# Altium schematic sheet RC96_sht1.SchDoc
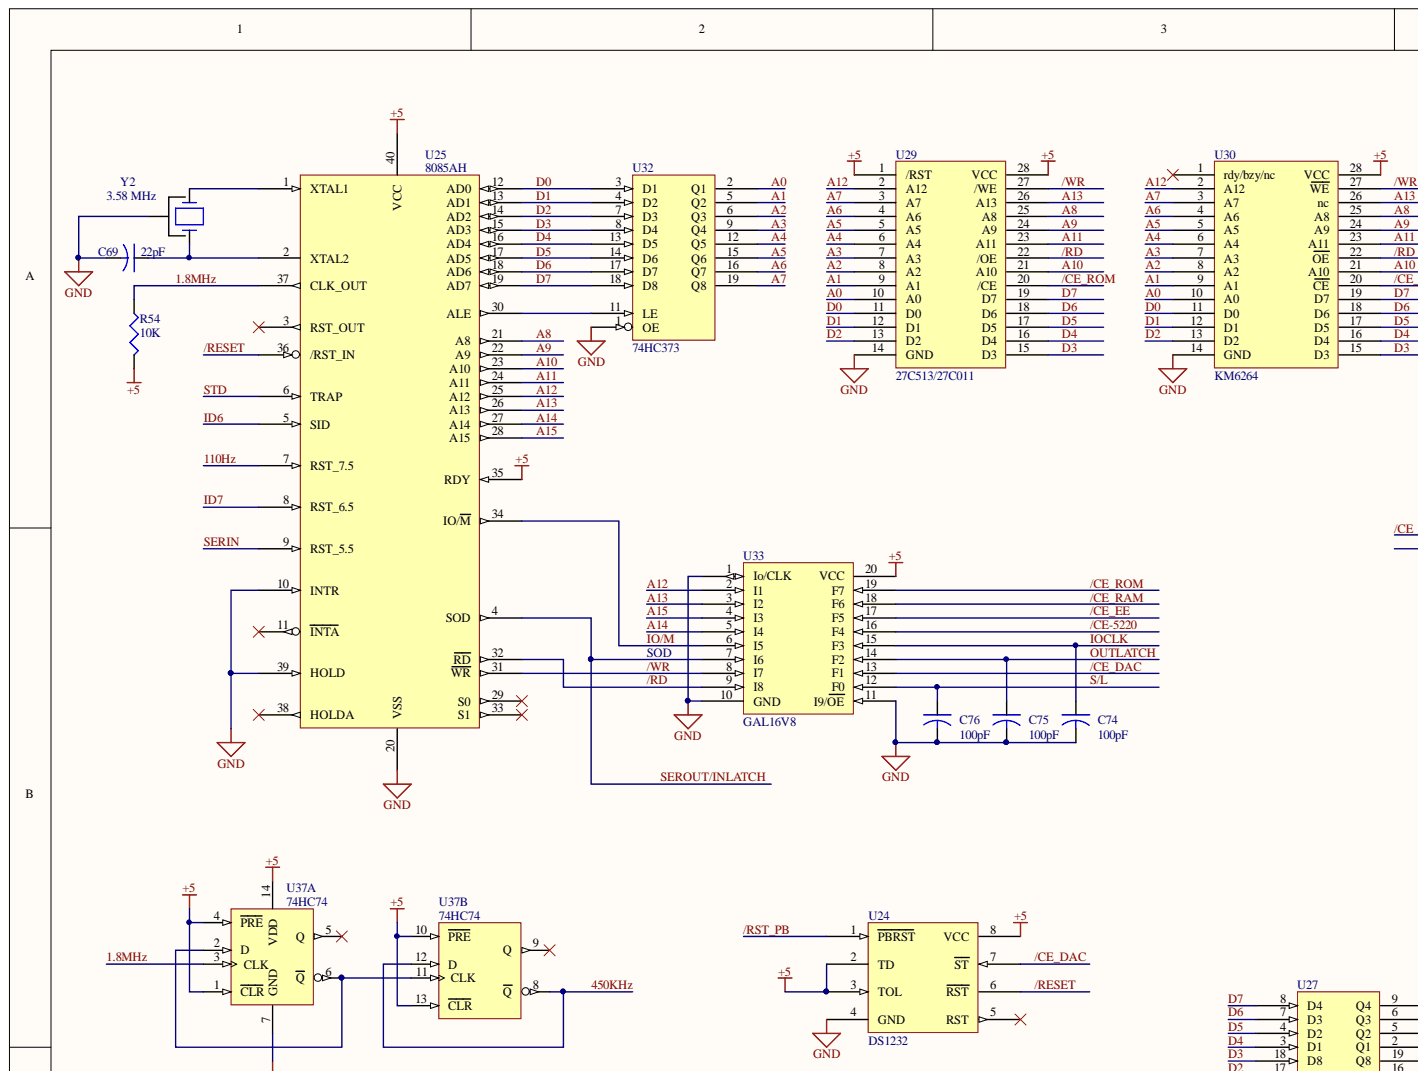
[diagram p0: RC96_sht1.SchDoc, top left]
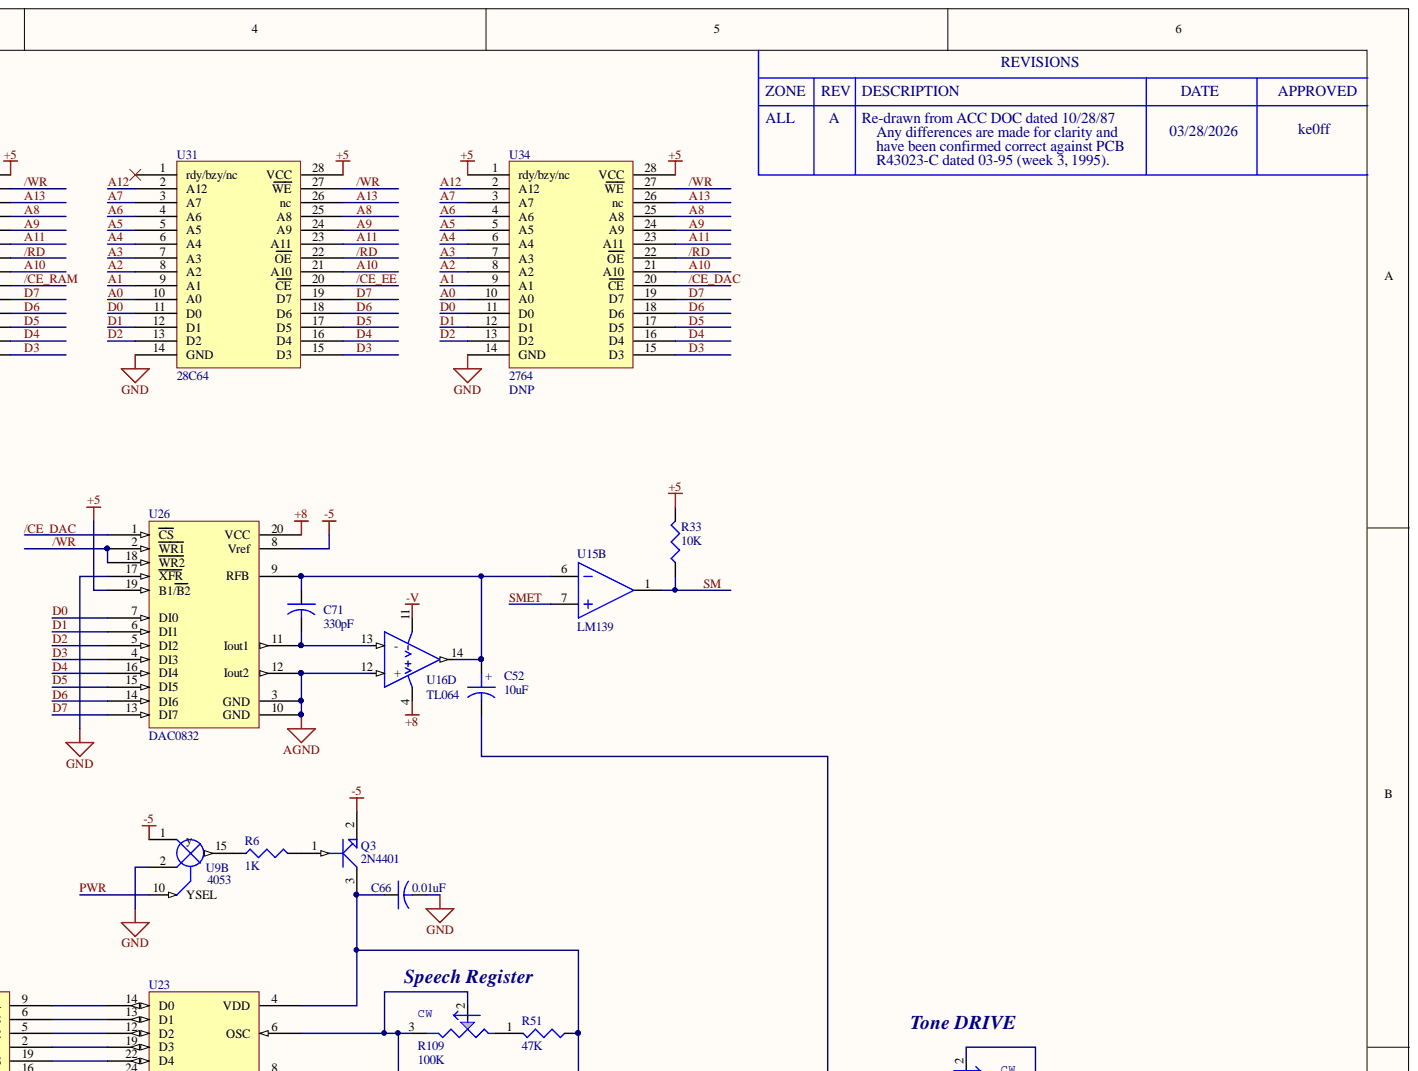
[diagram p1: RC96_sht1.SchDoc, top right]
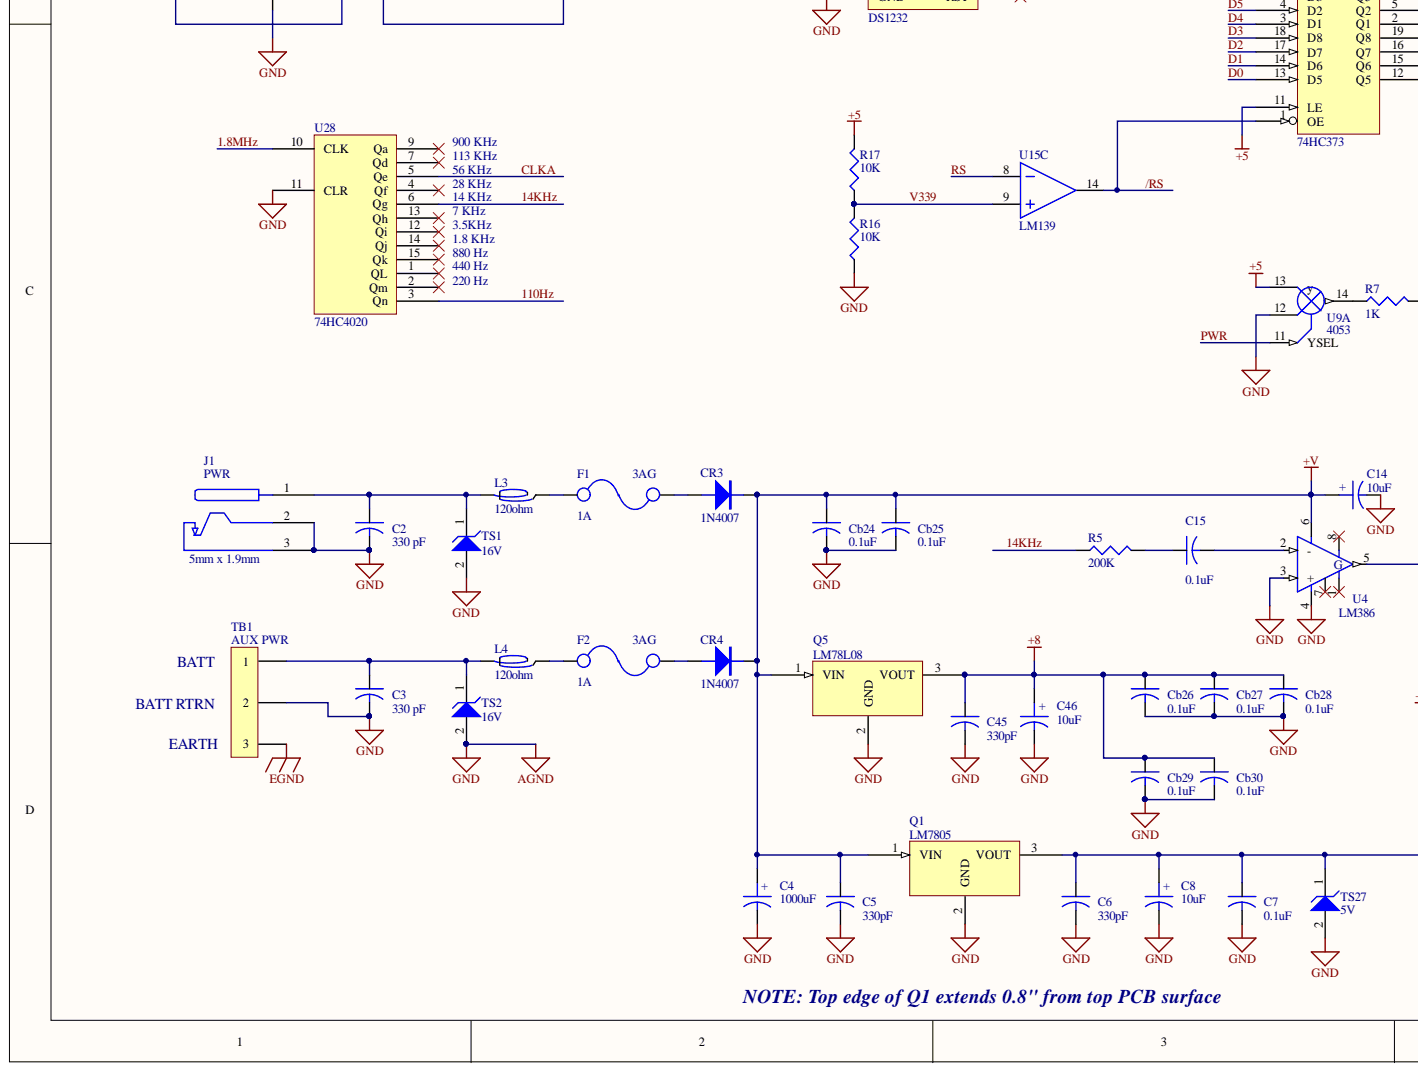
[diagram p2: RC96_sht1.SchDoc, bottom left]
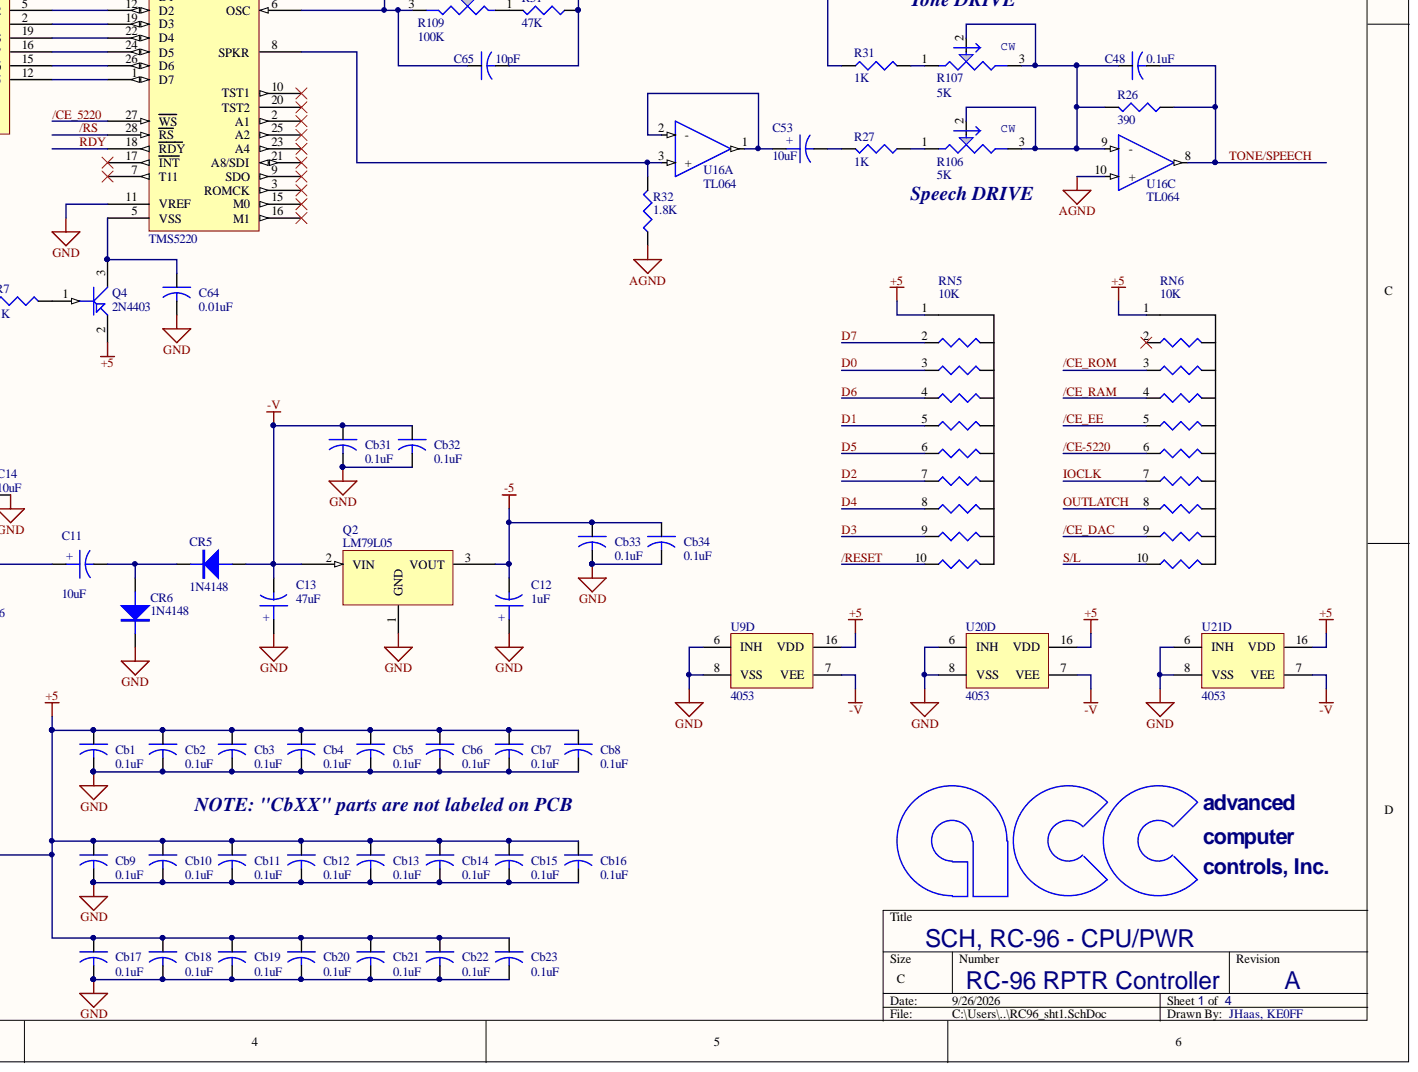
[diagram p3: RC96_sht1.SchDoc, bottom right]

## Parts
C2  330 pF  [RAD2]
C3  330 pF  [RAD2]
C4  1000uF  [RB.2/.4]
C5  330pF  [RAD2]
C6  330pF  [RAD2]
C7  0.1uF  [RAD2]
C8  10uF  [RB.05/.15]
C11  10uF  [RB.08/.2]
C12  1uF  [RB.05/.15]
C13  47uF  [RB.08/.2]
C14  10uF  [RB.05/.15]
C15  0.1uF  [RAD2]
C45  330pF  [RAD2]
C46  10uF  [RB.05/.15]
C48  0.1uF  [RAD2]
C52  10uF  [RB.05/.15]
C53  10uF  [RB.05/.15]
C64  0.01uF  [RAD2]
C65  10pF  [RAD2]
C66  0.01uF  [RAD2]
C69  22pF  [RAD2]
C71  330pF  [RAD2]
C74  100pF  [RAD2]
C75  100pF  [RAD2]
C76  100pF  [RAD2]
Cb1  0.1uF  [RAD2]
Cb2  0.1uF  [RAD2]
Cb3  0.1uF  [RAD2]
Cb4  0.1uF  [RAD2]
Cb5  0.1uF  [RAD2]
Cb6  0.1uF  [RAD2]
Cb7  0.1uF  [RAD2]
Cb8  0.1uF  [RAD2]
Cb9  0.1uF  [RAD2]
Cb10  0.1uF  [RAD2]
Cb11  0.1uF  [RAD2]
Cb12  0.1uF  [RAD2]
Cb13  0.1uF  [RAD2]
Cb14  0.1uF  [RAD2]
Cb15  0.1uF  [RAD2]
Cb16  0.1uF  [RAD2]
Cb17  0.1uF  [RAD2]
Cb18  0.1uF  [RAD2]
Cb19  0.1uF  [RAD2]
Cb20  0.1uF  [RAD2]
Cb21  0.1uF  [RAD2]
Cb22  0.1uF  [RAD2]
Cb23  0.1uF  [RAD2]
Cb24  0.1uF  [RAD2]
Cb25  0.1uF  [RAD2]
Cb26  0.1uF  [RAD2]
Cb27  0.1uF  [RAD2]
Cb28  0.1uF  [RAD2]
Cb29  0.1uF  [RAD2]
Cb30  0.1uF  [RAD2]
Cb31  0.1uF  [RAD2]
Cb32  0.1uF  [RAD2]
Cb33  0.1uF  [RAD2]
Cb34  0.1uF  [RAD2]
CR3  1N4007  [AXIAL4]
CR4  1N4007  [AXIAL4]
CR5  1N4148  [AXIAL3]
CR6  1N4148  [AXIAL3]
F1  1A  [3AG]
F2  1A  [3AG]
J1  PWR  NOTES: 5mm x 1.9mm  [CON_PWR_COAX_3]
L3  120ohm  [AXIAL10]
L4  120ohm  [AXIAL10]
Q1  LM7805  [TO-220_VERT]
Q2  LM79L05  [TO-92]
Q3  2N4401  [TO92]
Q4  2N4403  [TO92]
Q5  LM78L08  [TO-92]
R5  200K  [AXIAL4]
R6  1K  [AXIAL4]
R7  1K  [AXIAL4]
R16  10K  [AXIAL4]
R17  10K  [AXIAL4]
R26  390  [AXIAL4]
R27  1K  [AXIAL4]
R31  1K  [AXIAL4]
R32  1.8K  [AXIAL4]
R33  10K  [AXIAL4]
R51  47K  [AXIAL4]
R54  10K  [AXIAL4]
R106  5K  [TRI3]
R107  5K  [TRI3]
R109  100K  [TRI3]
RN5  10K  [SIP10]
RN6  10K  [SIP10]
TB1  AUX PWR  [TB3]
TS1  16V  [AXIAL6]
TS2  16V  [AXIAL6]
TS27  5V  [AXIAL6]
U4  LM386  [DIP8 - duplicate]
U9  4053  [DIP16]  (parts A,B,D)
U15  LM139  [SO14]  (parts B,C)
U16  TL064  [DIP14]  (parts A,C,D)
U20  4053  [DIP16]  (part D)
U21  4053  [DIP16]  (part D)
U23  TMS5220  [DIP28]
U24  DS1232  [DIP8]
U25  8085AH  [DIP40]
U26  DAC0832  [DIP20]
U27  74HC373  [DIP20]
U28  74HC4020  [DIP16]
U29  27C513/27C011  [DIP28]
U30  KM6264  [DIP28]
U31  28C64  [DIP28]
U32  74HC373  [DIP20]
U33  GAL16V8  [DIP20]
U34  2764  [DIP28]
U37  74HC74  [DIP14]  (parts A,B)
Y2  3.58 MHz  [HC-49/U]

## Nets
+5: C6.1 C7.1 C8.1 Cb1.1 Cb2.1 Cb3.1 Cb4.1 Cb5.1 Cb6.1 Cb7.1 Cb8.1 Cb9.1 Cb10.1 Cb11.1 Cb12.1 Cb13.1 Cb14.1 Cb15.1 Cb16.1 Cb17.1 Cb18.1 Cb19.1 Cb20.1 Cb21.1 Cb22.1 Cb23.1 Q1.3 Q4.2 R17.2 R33.2 R54.2 RN5.1 RN6.1 TS27.1 U9.13(y) U9.16(VDD) U20.16(VDD) U21.16(VDD) U24.2(TD) U24.3(TOL) U24.8(VCC) U25.35(RDY) U25.40(VCC) U26.19(B1/B2) U27.11(LE) U29.1(/RST) U29.28(VCC) U30.28(VCC) U31.28(VCC) U33.20(VCC) U34.1(rdy/bzy/nc) U34.28(VCC) U37.1(CLR) U37.4(PRE) U37.10(PRE) U37.13(CLR) U37.14(VDD)
+8: C45.1 C46.1 Cb26.1 Cb27.1 Cb28.1 Cb29.1 Cb30.1 Q5.3 U16.4 U26.20(VCC)
+V: C4.1 C5.1 C14.1 Cb24.1 Cb25.1 CR3.1 CR4.1 Q1.1 Q5.1 U4.6(-)
-5: C12.2 Cb33.1 Cb34.1 Q2.3 Q3.2 U9.1(y) U26.8(Vref)
-V: C13.2 Cb31.1 Cb32.1 CR5.2 Q2.2 U9.7(VEE) U16.11 U20.7(VEE) U21.7(VEE)
AGND: C2.2 C3.2 C4.2 C5.2 C6.2 C7.2 C8.2 C12.1 C13.1 C14.2 C45.2 C46.2 C64.2 C66.2 C69.2 C74.2 C75.2 C76.2 Cb1.2 Cb2.2 Cb3.2 Cb4.2 Cb5.2 Cb6.2 Cb7.2 Cb8.2 Cb9.2 Cb10.2 Cb11.2 Cb12.2 Cb13.2 Cb14.2 Cb15.2 Cb16.2 Cb17.2 Cb18.2 Cb19.2 Cb20.2 Cb21.2 Cb22.2 Cb23.2 Cb24.2 Cb25.2 Cb26.2 Cb27.2 Cb28.2 Cb29.2 Cb30.2 Cb31.2 Cb32.2 Cb33.2 Cb34.2 CR6.1 J1.2 J1.3 Q1.2 Q2.1 Q5.2 R16.1 R32.1 TB1.2 TS1.2 TS2.2 TS27.2 U4.3 U4.4(+) U9.2 U9.6(INH) U9.8(VSS) U9.12 U16.10(+) U16.12(+) U20.6(INH) U20.8(VSS) U21.6(INH) U21.8(VSS) U23.11(VREF) U24.4(GND) U25.10(INTR) U25.20(VSS) U25.39(HOLD) U26.3(GND) U26.10(GND) U26.12(Iout2) U26.17(XFR) U27.10 U28.8 U28.11(CLR) U29.14(GND) U30.14(GND) U31.14(GND) U32.1(OE) U32.10 U33.1(Io/CLK) U33.10(GND) U33.11(I9/OE) U34.14(GND) U37.7(GND) Y2.3
EGND: TB1.3
VCC: U25.23(A10) U25.44 U27.20 U28.16 U32.20
VSS: U25.1(XTAL1) U25.22(A9)
1.8MHZ: R54.1 U25.37(CLK_OUT) U28.10(CLK) U37.3(CLK)
14KHZ: R5.1 U28.6(Qg)
110HZ: U25.7(RST_7.5) U28.3(Qn)
450KHZ: U37.8(Q) U37.12(D)
/CE-5220: RN6.6 U33.16(F4)
/CE_5220: U23.27(WS)
/CE_DAC: RN6.9 U24.7(ST) U26.1(CS) U33.13(F1) U34.20(CE)
/CE_EE: RN6.5 U31.20(CE) U33.17(F5)
/CE_RAM: RN6.4 U30.20(CE) U33.18(F6)
/CE_ROM: RN6.3 U29.20(/CE) U33.19(F7)
/RD: U25.32(RD) U29.22(/OE) U30.22(OE) U31.22(OE) U33.9(I8) U34.22(OE)
/RESET: RN5.10 U24.6(RST) U25.36(/RST_IN)
/RS: U15.14 U23.28(RS) U27.1(OE)
/RST_PB: U24.1(PBRST)
/WR: U25.31(WR) U26.2(WR1) U26.18(WR2) U29.27(/WE) U30.27(WE) U31.27(WE) U33.8(I7) U34.27(WE)
A0: U29.10(A0) U30.10(A0) U31.10(A0) U32.2(Q1) U34.10(A0)
A1: U29.9(A1) U30.9(A1) U31.9(A1) U32.5(Q2) U34.9(A1)
A2: U29.8(A2) U30.8(A2) U31.8(A2) U32.6(Q3) U34.8(A2)
A3: U29.7(A3) U30.7(A3) U31.7(A3) U32.9(Q4) U34.7(A3)
A4: U29.6(A4) U30.6(A4) U31.6(A4) U32.12(Q5) U34.6(A4)
A5: U29.5(A5) U30.5(A5) U31.5(A5) U32.15(Q6) U34.5(A5)
A6: U29.4(A6) U30.4(A6) U31.4(A6) U32.16(Q7) U34.4(A6)
A7: U29.3(A7) U30.3(A7) U31.3(A7) U32.19(Q8) U34.3(A7)
A8: U25.21(A8) U29.25(A8) U30.25(A8) U31.25(A8) U34.25(A8)
A9: U25.22(A9) U29.24(A9) U30.24(A9) U31.24(A9) U34.24(A9)
A10: U25.23(A10) U29.21(A10) U30.21(A10) U31.21(A10) U34.21(A10)
A11: U25.24(A11) U29.23(A11) U30.23(A11) U31.23(A11) U34.23(A11)
A12: U25.25(A12) U29.2(A12) U30.2(A12) U31.2(A12) U33.2(I1) U34.2(A12)
A13: U25.26(A13) U29.26(A13) U30.26(nc) U31.26(nc) U33.3(I2) U34.26(nc)
A14: U25.27(A14) U33.5(I4)
A15: U25.28(A15) U33.4(I3)
CLKA: U28.5(Qe)
D0: RN5.3 U25.12(AD0) U26.7(DI0) U27.13(D5) U29.11(D0) U30.11(D0) U31.11(D0) U32.3(D1) U34.11(D0)
D1: RN5.5 U25.13(AD1) U26.6(DI1) U27.14(D6) U29.12(D1) U30.12(D1) U31.12(D1) U32.4(D2) U34.12(D1)
D2: RN5.7 U25.14(AD2) U26.5(DI2) U27.17(D7) U29.13(D2) U30.13(D2) U31.13(D2) U32.7(D3) U34.13(D2)
D3: RN5.9 U25.15(AD3) U26.4(DI3) U27.18(D8) U29.15(D3) U30.15(D3) U31.15(D3) U32.8(D4) U34.15(D3)
D4: RN5.8 U25.16(AD4) U26.16(DI4) U27.3(D1) U29.16(D4) U30.16(D4) U31.16(D4) U32.13(D5) U34.16(D4)
D5: RN5.6 U25.17(AD5) U26.15(DI5) U27.4(D2) U29.17(D5) U30.17(D5) U31.17(D5) U32.14(D6) U34.17(D5)
D6: RN5.4 U25.18(AD6) U26.14(DI6) U27.7(D3) U29.18(D6) U30.18(D6) U31.18(D6) U32.17(D7) U34.18(D6)
D7: RN5.2 U25.19(AD7) U26.13(DI7) U27.8(D4) U29.19(D7) U30.19(D7) U31.19(D7) U32.18(D8) U34.19(D7)
ID6: U25.5(SID)
ID7: U25.8(RST_6.5)
IO/M: U25.34(IO/M) U33.6(I5)
IOCLK: C74.1 RN6.7 U33.15(F3)
OUTLATCH: C75.1 RN6.8 U33.14(F2)
PWR: U9.10(YSEL) U9.11(YSEL)
RDY: U23.18(RDY)
RS: U15.8
S/L: C76.1 RN6.10 U33.12(F0)
SERIN: U25.9(RST_5.5)
SEROUT/INLATCH: U25.4(SOD) U33.7(I6)
SM: R33.1 U15.1
SMET: U15.7
STD: U25.6(TRAP)
TONE/SPEECH: C48.2 R26.1 U16.8
V339: R16.2 R17.1 U15.9
NetC2_1: C2.1 J1.1 L3.1 TS1.1
NetC3_1: C3.1 L4.1 TB1.1 TS2.1
NetC11_1: C11.1 U4.5
NetC11_2: C11.2 CR5.1 CR6.2
NetC15_1: C15.1 R5.2
NetC15_2: C15.2 U4.2
NetC48_1: C48.1 R26.2 R106.2 R106.3 R107.2 R107.3 U16.9(-)
NetC52_1: C52.1 C71.1 U15.6 U16.14 U26.9(RFB)
NetC52_2: C52.2 R31.1
NetC53_1: C53.1 U16.1 U16.2(-)
NetC53_2: C53.2 R27.2
NetC64_1: C64.1 Q4.3 U23.5(VSS)
NetC65_1: C65.1 R109.2 R109.3 U23.6(OSC)
NetC65_2: C65.2 C66.1 Q3.3 R51.1 U23.4(VDD)
NetC69_1: C69.1 U25.2(XTAL2) Y2.2
NetC71_2: C71.2 U16.13(-) U26.11(Iout1)
NetCR3_2: CR3.2 F1.2
NetCR4_2: CR4.2 F2.2
NetF1_1: F1.1 L3.2
NetF2_1: F2.1 L4.2
NetQ3_1: Q3.1 R6.2
NetQ4_1: Q4.1 R7.2
NetR6_1: R6.1 U9.15
NetR7_1: R7.1 U9.14
NetR27_1: R27.1 R106.1
NetR31_2: R31.2 R107.1
NetR32_2: R32.2 U16.3(+) U23.8(SPKR)
NetR51_2: R51.2 R109.1
NetU23_1: U23.1(D7) U27.12(Q5)
NetU23_12: U23.12(D2) U27.5(Q2)
NetU23_13: U23.13(D1) U27.6(Q3)
NetU23_14: U23.14(D0) U27.9(Q4)
NetU23_19: U23.19(D3) U27.2(Q1)
NetU23_22: U23.22(D4) U27.19(Q8)
NetU23_24: U23.24(D5) U27.16(Q7)
NetU23_26: U23.26(D6) U27.15(Q6)
NetU25_1: U25.1(XTAL1) Y2.1
NetU25_30: U25.30(ALE) U32.11(LE)
NetU37_2: U37.2(D) U37.6(Q) U37.11(CLK)

Not connected: RN6 2; U4 1(G) 7 8; U23 2(A1) 3(ROMCK) 7(T11) 9(SDO) 10(TST1) 15(M0) 16(M1) 17(INT) 20(TST2) 21(A8/SDI) 23(A4) 25(A2); U24 5(RST); U25 3(RST_OUT) 11(INTA) 29(S0) 33(S1) 38(HOLDA); U28 1(QL) 2(Qm) 4(Qf) 7(Qd) 9(Qa) 12(Qi) 13(Qh) 14(Qj) 15(Qk); U30 1(rdy/bzy/nc); U31 1(rdy/bzy/nc); U37 5(Q) 9(Q)

## Library data of the parts
C2 C3 C5-C7 C15 C45 C48 C64-C66 C69 C71 C74-C76 Cb1-Cb34 = CAP 0805: CAP, TANT, 0805, 50V, 1uF; ALT-MFR: -; ALT-MPN: -; Component Kind: Standard; DNP: -; MFR: -; MPN: -; Library Name: Galaxy SCH-jem-1.08.SchLib
C4 C8 C11-C14 C46 C52 C53 = CAP ELECTROLYTIC: ALT-MPN: -; Component Kind: Standard; DNP: -; MFR: -; MPN: -; NOTES: -; NOTES2: -; PRI-MPN: -; Library Name: Galaxy SCH-jem-1.08.SchLib
CR3-CR6 = DIODE-AXIAL: Diode; ALT-MPN: -; Component Kind: Standard; DNP: -; MFR: -; MPN: -; NOTES: -; NOTES2: -; PRI-MPN: -; Library Name: Galaxy SCH-jem-1.08.SchLib
F1 F2 = FUSE: ALT-MPN: -; Component Kind: Standard; Description: FUSE; DNP: -; MFR: -; MPN: -; NOTES: 3AG; NOTES2: -; PRI-MPN: -; Library Name: Galaxy SCH-jem-1.08.SchLib
J1 = CONN_COAXPWR_TAB: JACK, PWR, 3P, CTR=1; MFR: Switchcraft; MPN: RASM722BKZ; ALT-MPN: -; Component Kind: Standard; DNP: -; NOTES2: -; PRI-MPN: -; Library Name: Galaxy SCH-jem-1.08.SchLib
L3 L4 = FB2: BD, FRT, TH, 2LOOP; ALT-MPN: -; Component Kind: Standard; DNP: -; MFR: -; MPN: -; NOTES: -; NOTES2: -; PRI-MPN: -; Library Name: Galaxy SCH-jem-1.08.SchLib
Q1 Q5 = LM78L05: IC, REG, LIN, FXD, LWPWR, 5.0V; ALT-MPN: -; Component Kind: Standard; DNP: -; MFR: -; MPN: -; NOTES: -; NOTES2: -; PRI-MPN: -; Library Name: Galaxy SCH-jem-1.08.SchLib
Q2 = LM79L05: IC, REG, LIN, FXD, LWPWR, -5.0V; ALT-MPN: -; Component Kind: Standard; DNP: -; MFR: -; MPN: -; NOTES: -; NOTES2: -; PRI-MPN: -; Library Name: Galaxy SCH-jem-1.08.SchLib
Q3 = NPN1: TR, NPN; ALT-MPN: -; Component Kind: Standard; DNP: -; MFR: -; MPN: -; NOTES: -; NOTES2: -; PRI-MPN: -; Library Name: Galaxy SCH-jem-1.08.SchLib
Q4 = PNP1: TR, PNP; ALT-MPN: -; Component Kind: Standard; DNP: -; MFR: -; MPN: -; NOTES: -; NOTES2: -; PRI-MPN: -; Library Name: Galaxy SCH-jem-1.08.SchLib
R5-R7 R16 R17 R26 R27 R31-R33 R51 R54 = R0805: RES, CHP, 0201, 1%, 4.7K; ALT-MPN: -; Component Kind: Standard; DNP: -; MFR: -; MPN: -; NOTES: -; NOTES2: -; PRI-MPN: -; Library Name: Galaxy SCH-jem-1.08.SchLib
R106 R107 R109 = RESISTOR VAR: RES, VAR; ALT-MPN: -; Component Kind: Standard; DNP: -; MFR: -; MPN: -; NOTES: -; NOTES2: -; PRI-MPN: -; Library Name: Galaxy SCH-jem-1.08.SchLib
RN5 RN6 = RP9: RES, NET, SIP, 9RES; ALT-MPN: -; Component Kind: Standard; DNP: -; MFR: -; MPN: -; NOTES: -; NOTES2: -; PRI-MPN: -; Library Name: Galaxy SCH-jem-1.08.SchLib
TB1 = CON3_TB: CON, TB, 3 POS; ALT-MPN: -; Component Kind: Standard; DNP: -; MFR: -; MPN: -; NOTES: -; NOTES2: -; PRI-MPN: -; Library Name: Galaxy SCH-jem-1.08.SchLib
TS1 TS2 TS27 = TVS_UNI: DIO, TVS, UNIDIR; ALT-MPN: -; Component Kind: Standard; DNP: -; MFR: -; MPN: -; NOTES: -; NOTES2: -; PRI-MPN: -; Library Name: Galaxy SCH-jem-1.08.SchLib
U4 = LM386: IC, AMP, SNGL, SPKR, 8ohm; MFR: TI; MPN: LM386N-3/NOPB; ALT-MPN: -; Component Kind: Standard; DNP: -; NOTES: -; NOTES2: -; PRI-MPN: -; Library Name: Galaxy SCH-jem-1.08.SchLib
U9 U20 U21 = 4053_ALT: IC, SW, ANALOG, TRI; ALT-MPN: -; Component Kind: Standard; DNP: -; MFR: -; MPN: -; NOTES: -; NOTES2: -; PRI-MPN: -; Library Name: Galaxy SCH-jem-1.08.SchLib
U15 = LM139: IC, OPAMP, QUAD; ALT-MPN: -; Component Kind: Standard; DNP: -; MFR: -; MPN: -; NOTES: -; NOTES2: -; PRI-MPN: -; Library Name: Galaxy SCH-jem-1.08.SchLib
U16 = TL084: IC, OPAMP, JFET, QUAD; ALT-MPN: -; Component Kind: Standard; DNP: -; MFR: -; MPN: -; NOTES: -; NOTES2: -; PRI-MPN: -; Library Name: Galaxy SCH-jem-1.08.SchLib
U23 = TMS5220: IC, SPCH, LPC; MFR: TI; MPN: TMS5220CNL; Component Kind: Standard; DNP: -; Library Name: Galaxy SCH-jem-1.08.SchLib
U24 = DS1232: IC, CNTLR, RESET; MFR: Maxim; ALT-MPN: -; Component Kind: Standard; DNP: -; MPN: DS1232; NOTES: -; NOTES2: -; PRI-MPN: -; Library Name: Galaxy SCH-jem-1.08.SchLib
U25 = 8085AH: IC, MCU, DIP40; MFR: INTEL; ALT-MPN: -; Component Kind: Standard; DNP: -; MPN: 8085AH; NOTES: -; NOTES2: -; PRI-MPN: -; Library Name: Galaxy SCH-jem-1.08.SchLib
U26 = DAC0832: IC, DAC, PRLL, 8b, 1CH; MFR: National Semiconductor; ALT-MPN: -; Component Kind: Standard; DNP: -; MPN: DAC0832; NOTES: -; NOTES2: -; PRI-MPN: -; Library Name: Galaxy SCH-jem-1.08.SchLib
U27 = 74HC373_ALT: IC, LGC, REG, OCTL; ALT-MPN: -; Component Kind: Standard; DNP: -; MFR: -; MPN: -; NOTES: -; NOTES2: -; PRI-MPN: -; Library Name: Galaxy SCH-jem-1.08.SchLib
U28 = 74HC4020: IC, LGC, CNTR/DVDR, RPPL, Q13; ALT-MPN: -; Component Kind: Standard; DNP: -; MFR: -; MPN: -; NOTES: -; NOTES2: -; PRI-MPN: -; Library Name: Galaxy SCH-jem-1.08.SchLib
U29 = 27C513: IC, EPROM, 512Kb, x8, BANKED, DIP; MPN: 27C011-20; Component Kind: Standard; Library Name: Galaxy SCH-jem-1.08.SchLib
U30 = 28C64: IC, SRAM, 8KX8; ALT-MPN: -; Component Kind: Standard; DNP: -; MFR: -; MPN: KM6264; NOTES: -; NOTES2: -; PRI-MPN: -; Library Name: Galaxy SCH-jem-1.08.SchLib
U31 U34 = 28C64: IC, EEPROM, 8KX8; ALT-MPN: -; Component Kind: Standard; MFR: -; NOTES: -; NOTES2: -; PRI-MPN: -; Library Name: Galaxy SCH-jem-1.08.SchLib
  U31: DNP: -; MPN: 28C64
  U34: DNP: DNP; MPN: 2764
U32 = 74HC373: IC, LGC, REG, OCTL; ALT-MPN: -; Component Kind: Standard; DNP: -; MFR: -; MPN: 74HC373; NOTES: -; NOTES2: -; PRI-MPN: -; Library Name: Galaxy SCH-jem-1.08.SchLib
U33 = GAL16V8: IC, LGC, PAL, EE, 16V8; MFR: Lattice; Component Kind: Standard; DNP: -; MPN: GAL16V8; Library Name: Galaxy SCH-jem-1.08.SchLib
U37 = 74HC74: IC, FF, D, PET, FF, RS, CLR; ALT-MPN: -; Component Kind: Standard; DNP: -; MFR: -; MPN: -; NOTES: -; NOTES2: -; PRI-MPN: -; Library Name: Galaxy SCH-jem-1.08.SchLib
Y2 = CRYSTAL-3PIN_SH: XTAL, 3-PIN (SH); ALT-MPN: -; Component Kind: Standard; DNP: -; MFR: -; MPN: -; NOTES: -; NOTES2: -; PRI-MPN: -; Library Name: Galaxy SCH-jem-1.08.SchLib
